# Altium schematic sheet SCM20260E-Micro.SchDoc
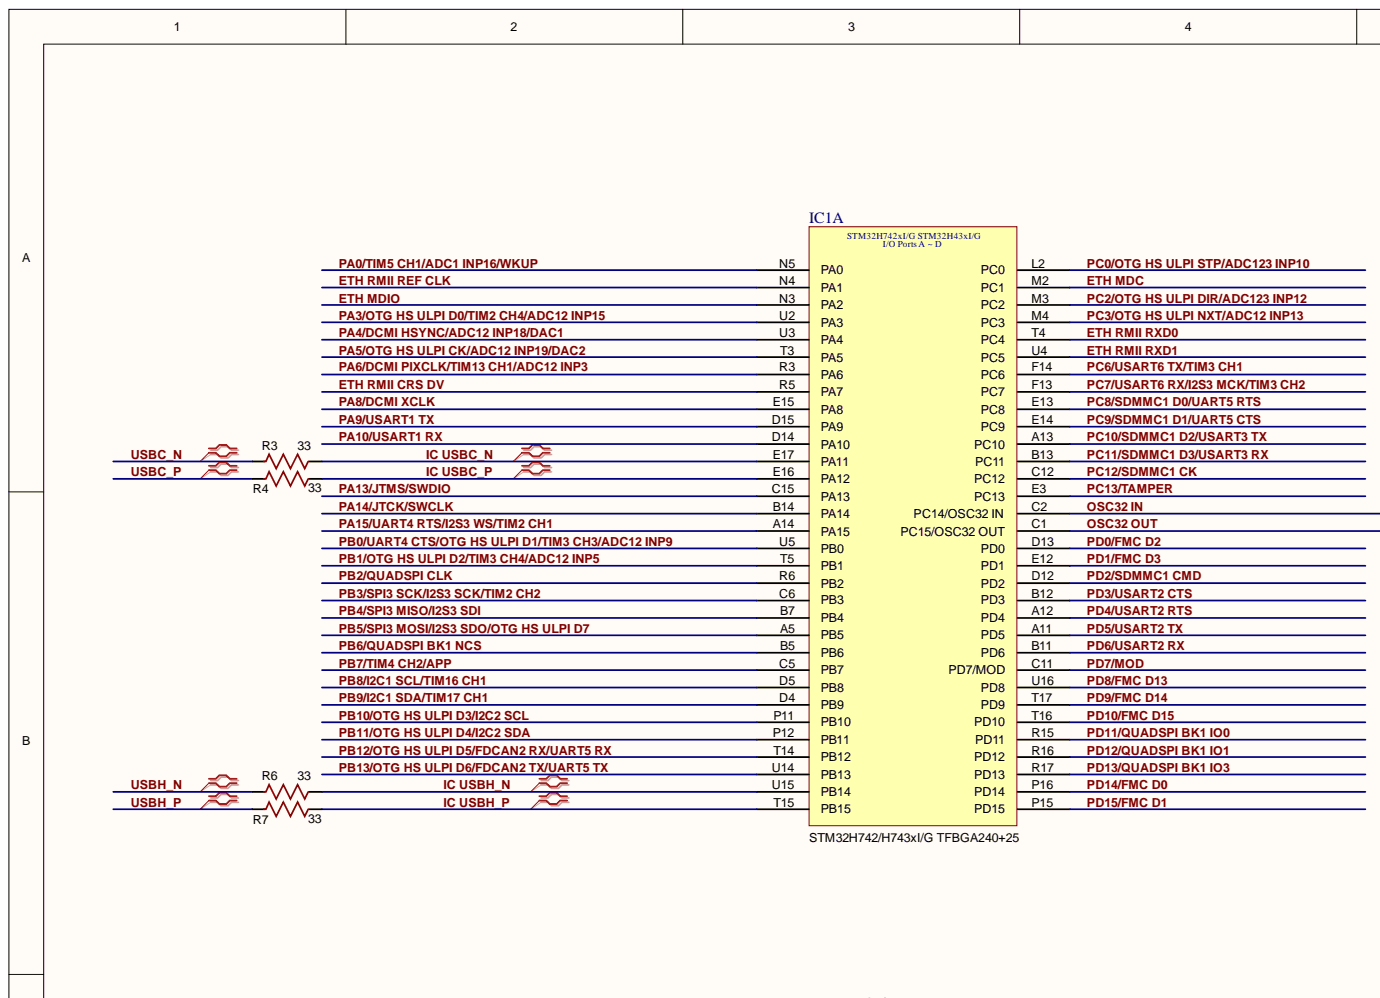
[diagram p0: SCM20260E-Micro.SchDoc, top left]
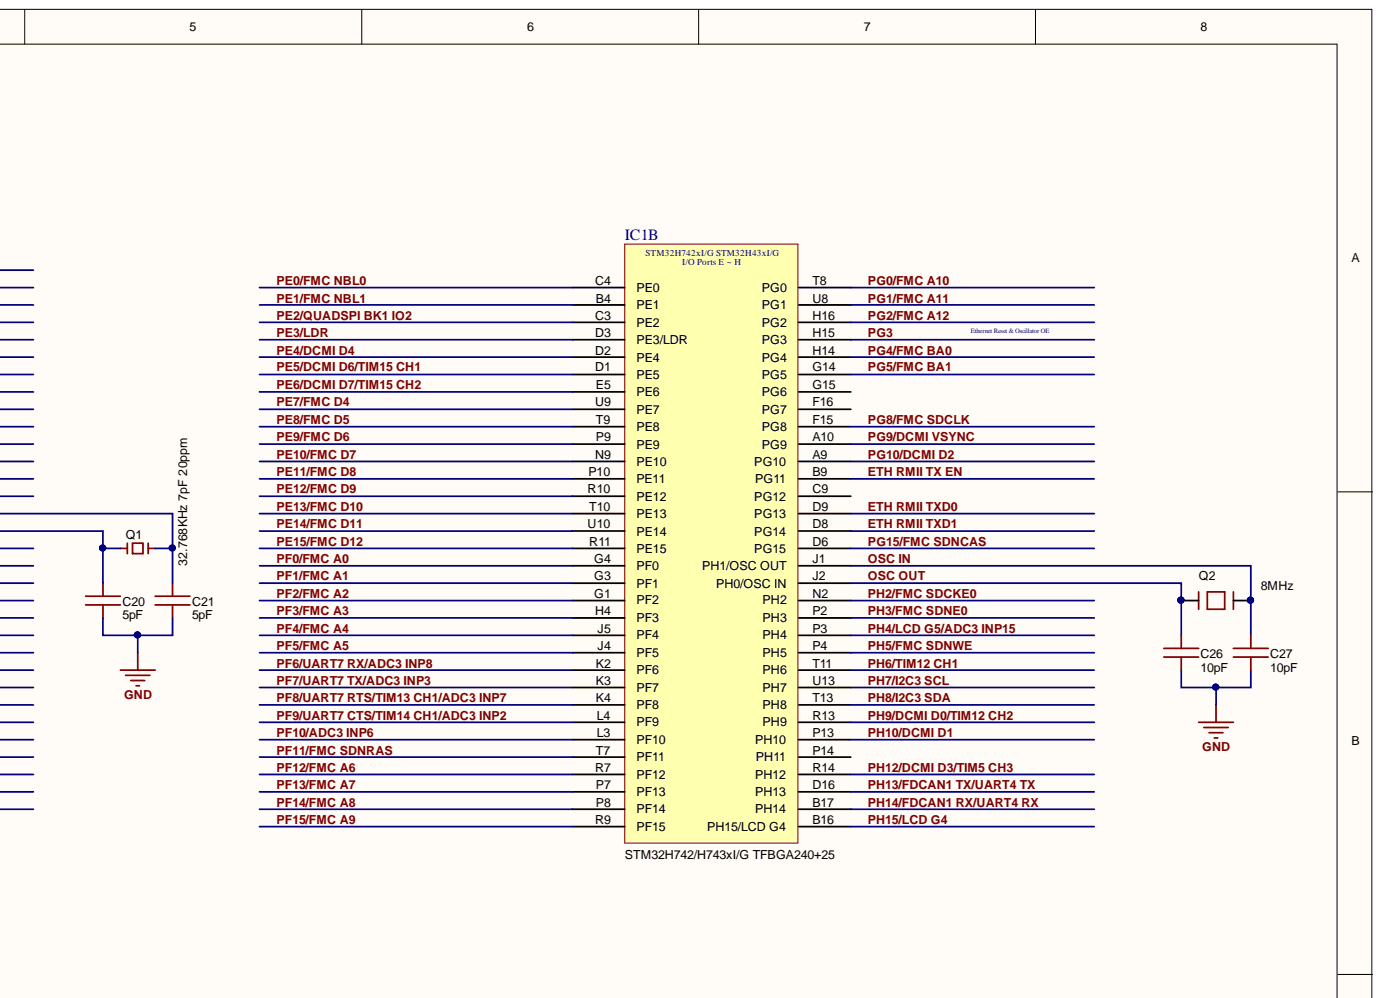
[diagram p1: SCM20260E-Micro.SchDoc, top right]
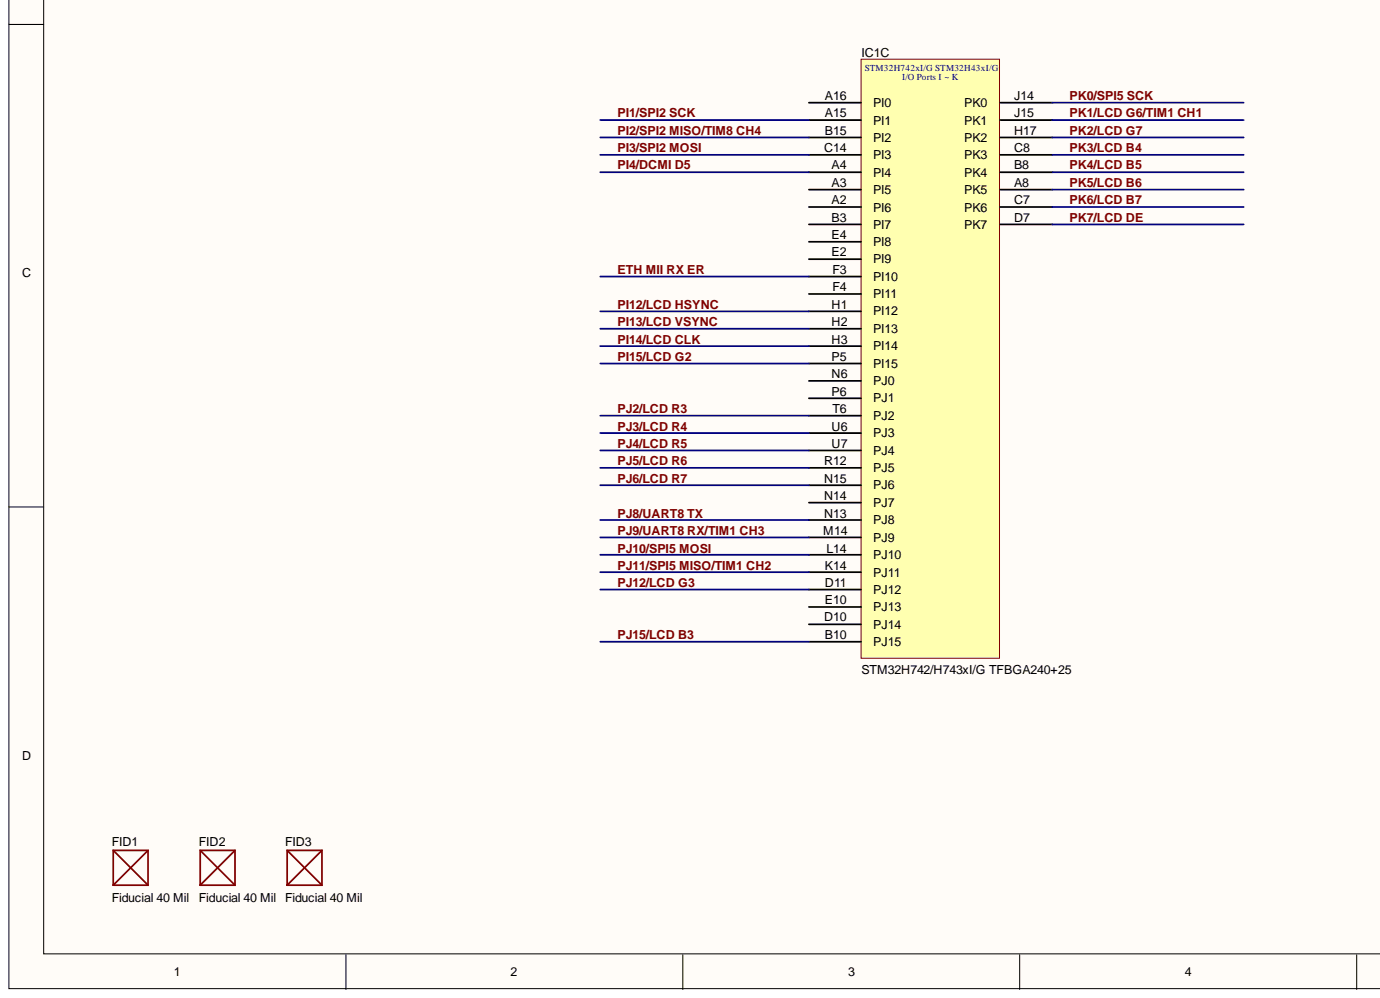
[diagram p2: SCM20260E-Micro.SchDoc, bottom left]
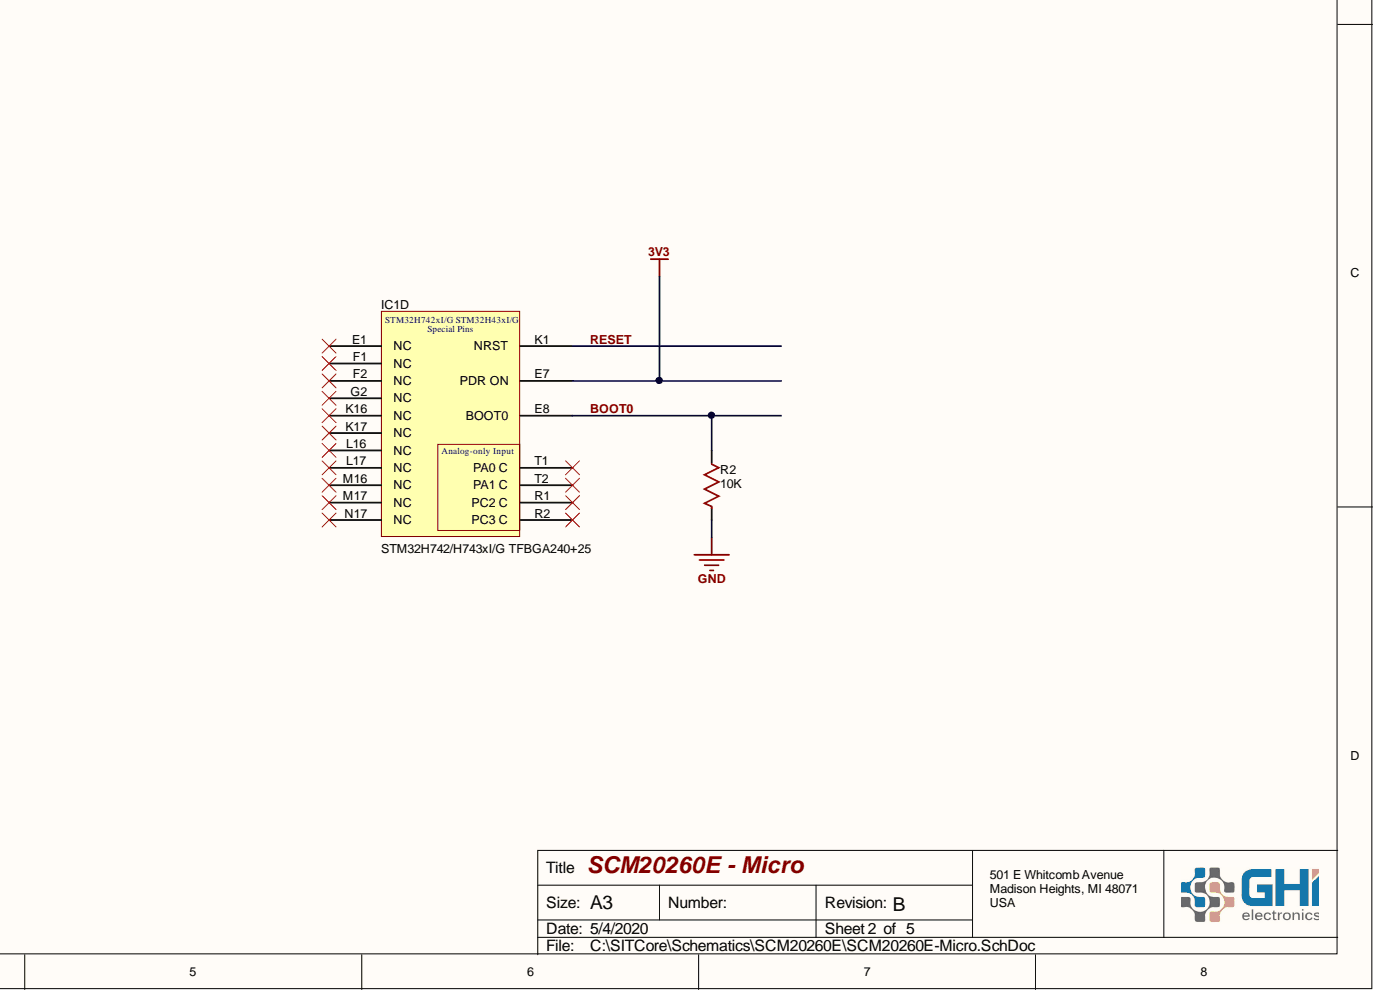
[diagram p3: SCM20260E-Micro.SchDoc, bottom right]

## Parts
C20  value 5pF  Capacitance: 5pF  [C0402]  (hidden comment: 0402 Cap 5pF)
C21  value 5pF  Capacitance: 5pF  [C0402]  (hidden comment: 0402 Cap 5pF)
C26  value 10pF  Capacitance: 10pF  [C0402]  (hidden comment: 0402 Cap 10pF)
C27  value 10pF  Capacitance: 10pF  [C0402]  (hidden comment: 0402 Cap 10pF)
FID1  Fiducial 40 Mil  [Fiducial 40 Mil]  (no BOM)
FID2  Fiducial 40 Mil  [Fiducial 40 Mil]  (no BOM)
FID3  Fiducial 40 Mil  [Fiducial 40 Mil]  (no BOM)
IC1  STM32H742/H743xI/G TFBGA240+25  [TFBGA240+25]  (parts A,B,C,D)
Q1  32.768KHz 7pF 20ppm  Output Frequency: 32.768KHz  [Crystal 3.2mm x 1.5mm]
Q2  value 8MHz  [CRYSTAL_3.2X2.5]  (hidden comment: CRYSTAL3.2X2.5)
R2  value 10K  Resistance: 10K  [R0402]  (hidden comment: 0402 Res 10K)
R3  value 33  [R0402 (Metric 1005)]  (hidden comment: 0402 R)
R4  value 33  [R0402 (Metric 1005)]  (hidden comment: 0402 R)
R6  value 33  [R0402 (Metric 1005)]  (hidden comment: 0402 R)
R7  value 33  [R0402 (Metric 1005)]  (hidden comment: 0402 R)

## Nets
3V3: IC1.E7(PDR_ON)
GND: C20.1 C21.1 C26.1 C27.1 R2.1
BOOT0: IC1.E8(BOOT0) R2.2
ETH MDC: IC1.M2(PC1)
ETH MDIO: IC1.N3(PA2)
ETH MII RX ER: IC1.F3(PI10)
ETH RMII CRS DV: IC1.R5(PA7)
ETH RMII REF CLK: IC1.N4(PA1)
ETH RMII RXD0: IC1.T4(PC4)
ETH RMII RXD1: IC1.U4(PC5)
ETH RMII TX EN: IC1.B9(PG11)
ETH RMII TXD0: IC1.D9(PG13)
ETH RMII TXD1: IC1.D8(PG14)
IC USBC_N: IC1.E17(PA11) R3.1
IC USBC_P: IC1.E16(PA12) R4.1
IC USBH_N: IC1.U15(PB14) R6.1
IC USBH_P: IC1.T15(PB15) R7.1
OSC32 IN: C21.2 IC1.C2(PC14/OSC32_IN) Q1.2
OSC32 OUT: C20.2 IC1.C1(PC15/OSC32_OUT) Q1.1
OSC IN: C27.2 IC1.J1(PH1/OSC_OUT) Q2.3
OSC OUT: C26.2 IC1.J2(PH0/OSC_IN) Q2.1
PA0/TIM5 CH1/ADC1 INP16/WKUP: IC1.N5(PA0)
PA3/OTG HS ULPI D0/TIM2 CH4/ADC12 INP15: IC1.U2(PA3)
PA4/DCMI HSYNC/ADC12 INP18/DAC1: IC1.U3(PA4)
PA5/OTG HS ULPI CK/ADC12 INP19/DAC2: IC1.T3(PA5)
PA6/DCMI PIXCLK/TIM13 CH1/ADC12 INP3: IC1.R3(PA6)
PA8/DCMI XCLK: IC1.E15(PA8)
PA9/USART1 TX: IC1.D15(PA9)
PA10/USART1 RX: IC1.D14(PA10)
PA13/JTMS/SWDIO: IC1.C15(PA13)
PA14/JTCK/SWCLK: IC1.B14(PA14)
PA15/UART4 RTS/I2S3 WS/TIM2 CH1: IC1.A14(PA15)
PB0/UART4 CTS/OTG HS ULPI D1/TIM3 CH3/ADC12 INP9: IC1.U5(PB0)
PB1/OTG HS ULPI D2/TIM3 CH4/ADC12 INP5: IC1.T5(PB1)
PB2/QUADSPI CLK: IC1.R6(PB2)
PB3/SPI3 SCK/I2S3 SCK/TIM2 CH2: IC1.C6(PB3)
PB4/SPI3 MISO/I2S3 SDI: IC1.B7(PB4)
PB5/SPI3 MOSI/I2S3 SDO/OTG HS ULPI D7: IC1.A5(PB5)
PB6/QUADSPI BK1 NCS: IC1.B5(PB6)
PB7/TIM4 CH2/APP: IC1.C5(PB7)
PB8/I2C1 SCL/TIM16 CH1: IC1.D5(PB8)
PB9/I2C1 SDA/TIM17 CH1: IC1.D4(PB9)
PB10/OTG HS ULPI D3/I2C2 SCL: IC1.P11(PB10)
PB11/OTG HS ULPI D4/I2C2 SDA: IC1.P12(PB11)
PB12/OTG HS ULPI D5/FDCAN2 RX/UART5 RX: IC1.T14(PB12)
PB13/OTG HS ULPI D6/FDCAN2 TX/UART5 TX: IC1.U14(PB13)
PC0/OTG HS ULPI STP/ADC123 INP10: IC1.L2(PC0)
PC2/OTG HS ULPI DIR/ADC123 INP12: IC1.M3(PC2)
PC3/OTG HS ULPI NXT/ADC12 INP13: IC1.M4(PC3)
PC6/USART6 TX/TIM3 CH1: IC1.F14(PC6)
PC7/USART6 RX/I2S3 MCK/TIM3 CH2: IC1.F13(PC7)
PC8/SDMMC1 D0/UART5 RTS: IC1.E13(PC8)
PC9/SDMMC1 D1/UART5 CTS: IC1.E14(PC9)
PC10/SDMMC1 D2/USART3 TX: IC1.A13(PC10)
PC11/SDMMC1 D3/USART3 RX: IC1.B13(PC11)
PC12/SDMMC1 CK: IC1.C12(PC12)
PC13/TAMPER: IC1.E3(PC13)
PD0/FMC D2: IC1.D13(PD0)
PD1/FMC D3: IC1.E12(PD1)
PD2/SDMMC1 CMD: IC1.D12(PD2)
PD3/USART2 CTS: IC1.B12(PD3)
PD4/USART2 RTS: IC1.A12(PD4)
PD5/USART2 TX: IC1.A11(PD5)
PD6/USART2 RX: IC1.B11(PD6)
PD7/MOD: IC1.C11(PD7/MOD)
PD8/FMC D13: IC1.U16(PD8)
PD9/FMC D14: IC1.T17(PD9)
PD10/FMC D15: IC1.T16(PD10)
PD11/QUADSPI BK1 IO0: IC1.R15(PD11)
PD12/QUADSPI BK1 IO1: IC1.R16(PD12)
PD13/QUADSPI BK1 IO3: IC1.R17(PD13)
PD14/FMC D0: IC1.P16(PD14)
PD15/FMC D1: IC1.P15(PD15)
PE0/FMC NBL0: IC1.C4(PE0)
PE1/FMC NBL1: IC1.B4(PE1)
PE2/QUADSPI BK1 IO2: IC1.C3(PE2)
PE3/LDR: IC1.D3(PE3/LDR)
PE4/DCMI D4: IC1.D2(PE4)
PE5/DCMI D6/TIM15 CH1: IC1.D1(PE5)
PE6/DCMI D7/TIM15 CH2: IC1.E5(PE6)
PE7/FMC D4: IC1.U9(PE7)
PE8/FMC D5: IC1.T9(PE8)
PE9/FMC D6: IC1.P9(PE9)
PE10/FMC D7: IC1.N9(PE10)
PE11/FMC D8: IC1.P10(PE11)
PE12/FMC D9: IC1.R10(PE12)
PE13/FMC D10: IC1.T10(PE13)
PE14/FMC D11: IC1.U10(PE14)
PE15/FMC D12: IC1.R11(PE15)
PF0/FMC A0: IC1.G4(PF0)
PF1/FMC A1: IC1.G3(PF1)
PF2/FMC A2: IC1.G1(PF2)
PF3/FMC A3: IC1.H4(PF3)
PF4/FMC A4: IC1.J5(PF4)
PF5/FMC A5: IC1.J4(PF5)
PF6/UART7 RX/ADC3 INP8: IC1.K2(PF6)
PF7/UART7 TX/ADC3 INP3: IC1.K3(PF7)
PF8/UART7 RTS/TIM13 CH1/ADC3 INP7: IC1.K4(PF8)
PF9/UART7 CTS/TIM14 CH1/ADC3 INP2: IC1.L4(PF9)
PF10/ADC3 INP6: IC1.L3(PF10)
PF11/FMC SDNRAS: IC1.T7(PF11)
PF12/FMC A6: IC1.R7(PF12)
PF13/FMC A7: IC1.P7(PF13)
PF14/FMC A8: IC1.P8(PF14)
PF15/FMC A9: IC1.R9(PF15)
PG0/FMC A10: IC1.T8(PG0)
PG1/FMC A11: IC1.U8(PG1)
PG2/FMC A12: IC1.H16(PG2)
PG3: IC1.H15(PG3)
PG4/FMC BA0: IC1.H14(PG4)
PG5/FMC BA1: IC1.G14(PG5)
PG8/FMC SDCLK: IC1.F15(PG8)
PG9/DCMI VSYNC: IC1.A10(PG9)
PG10/DCMI D2: IC1.A9(PG10)
PG15/FMC SDNCAS: IC1.D6(PG15)
PH2/FMC SDCKE0: IC1.N2(PH2)
PH3/FMC SDNE0: IC1.P2(PH3)
PH4/LCD G5/ADC3 INP15: IC1.P3(PH4)
PH5/FMC SDNWE: IC1.P4(PH5)
PH6/TIM12 CH1: IC1.T11(PH6)
PH7/I2C3 SCL: IC1.U13(PH7)
PH8/I2C3 SDA: IC1.T13(PH8)
PH9/DCMI D0/TIM12 CH2: IC1.R13(PH9)
PH10/DCMI D1: IC1.P13(PH10)
PH12/DCMI D3/TIM5 CH3: IC1.R14(PH12)
PH13/FDCAN1 TX/UART4 TX: IC1.D16(PH13)
PH14/FDCAN1 RX/UART4 RX: IC1.B17(PH14)
PH15/LCD G4: IC1.B16(PH15/LCD_G4)
PI1/SPI2 SCK: IC1.A15(PI1)
PI2/SPI2 MISO/TIM8 CH4: IC1.B15(PI2)
PI3/SPI2 MOSI: IC1.C14(PI3)
PI4/DCMI D5: IC1.A4(PI4)
PI12/LCD HSYNC: IC1.H1(PI12)
PI13/LCD VSYNC: IC1.H2(PI13)
PI14/LCD CLK: IC1.H3(PI14)
PI15/LCD G2: IC1.P5(PI15)
PJ2/LCD R3: IC1.T6(PJ2)
PJ3/LCD R4: IC1.U6(PJ3)
PJ4/LCD R5: IC1.U7(PJ4)
PJ5/LCD R6: IC1.R12(PJ5)
PJ6/LCD R7: IC1.N15(PJ6)
PJ8/UART8 TX: IC1.N13(PJ8)
PJ9/UART8 RX/TIM1 CH3: IC1.M14(PJ9)
PJ10/SPI5 MOSI: IC1.L14(PJ10)
PJ11/SPI5 MISO/TIM1 CH2: IC1.K14(PJ11)
PJ12/LCD G3: IC1.D11(PJ12)
PJ15/LCD B3: IC1.B10(PJ15)
PK0/SPI5 SCK: IC1.J14(PK0)
PK1/LCD G6/TIM1 CH1: IC1.J15(PK1)
PK2/LCD G7: IC1.H17(PK2)
PK3/LCD B4: IC1.C8(PK3)
PK4/LCD B5: IC1.B8(PK4)
PK5/LCD B6: IC1.A8(PK5)
PK6/LCD B7: IC1.C7(PK6)
PK7/LCD DE: IC1.D7(PK7)
RESET: IC1.K1(NRST)
USBC_N: R3.2
USBC_P: R4.2
USBH_N: R6.2
USBH_P: R7.2

Not connected: IC1 A2(PI6) A3(PI5) A16(PI0) B3(PI7) C9(PG12) D10(PJ14) E1(NC) E2(PI9) E4(PI8) E10(PJ13) F1(NC) F2(NC) F4(PI11) F16(PG7) G2(NC) G15(PG6) K16(NC) K17(NC) L16(NC) L17(NC) M16(NC) M17(NC) N6(PJ0) N14(PJ7) N17(NC) P6(PJ1) P14(PH11) R1(PC2_C) R2(PC3_C) T1(PA0_C) T2(PA1_C)

## Library data of the parts
C20 C21 = 0402 Cap 5pF: 5pF ±0.1pF 50V C0G 0402 Multilayer Ceramic Capacitors MLCC - SMD/SMT RoHS; Manufacturer: Samsung Electro-Mechanics; Mfr.Part #: CL05C050BB5NNNC; Category: Ceramic; Component Kind: Standard; LCSC Part #: C318616; Package: 0402; Tolerance: ±0.1pF; Voltage: 50V; Library Name: GHI-Passives.SchLib
C26 C27 = 0402 Cap 10pF: 10pF ±0.5pF 50V C0G 0402 Multilayer Ceramic Capacitors MLCC - SMD/SMT RoHS; Manufacturer: Samsung Electro-Mechanics; Mfr.Part #: CL05C100DB5NNNC; Category: Ceramic; Component Kind: Standard; LCSC Part #: C307440; Package: 0402; Tolerance: ±0.5pF; Voltage: 50V; Library Name: GHI-Passives.SchLib
IC1 = STM32H742/H743xI/G TFBGA240+25: STM32H742/H743xI/G TFBGA240+25 (14 x 14mm); Component Kind: Standard; Library Name: GHI Processors.SchLib
Q1 = 32.768KHz 7pF 20ppm 2 Pad 3.2mm x 1.5mm: Crystal 2 Pad 3.2mm x 1.5mm 32.768KHz; GHI Part Number: EPC-Q32KHZ; Manufacturer Part Number: 6K30032768K0702070401; Aging @25 C 1st Year (Max): +- 3ppm/year; Driver Level (Typical): 1uW Max; Equivalent Series Resistance: 70k Ohm Max; Frequency Tolerance: +- 20ppm; Load Capacitance: 10 pF; Mode: AT/Fundamental; Shunt Capacitance: 1.3pF Typ; Component Kind: Standard; Frequency Stability: N/A; Library Name: GHI Oscillators.SchLib; Operating Temperature Range: -40 C to +85 C; Shock Resistance: N/A; Storage Temperature: -55C to +125 C
Q2 = CRYSTAL3.2X2.5: 4 pad 3.2 mm x 2.5 mm Crystal; GateName_1: G$1; SymbolName_1: CRYSTAL.2PAD; Component Kind: Standard; DeviceSetName: CRYSTAL3.2X2.5; LibraryName: GHI2; Package: 3225; Library Name: GHI.SchLib
R2 = 0402 Res 10K: 10K ±1% 1/16W ±100ppm/℃ 0402 Chip Resistor - Surface Mount RoHS; Manufacturer: YAGEO; Mfr.Part #: RC0402FR-0710KL; Voltage Rating: *V; Component Kind: Standard; LCSC Part #: C60490; Package: 0402; Power Rating: 1/16W; Resistance Tolerance: ±1%; Library Name: GHI-Passives.SchLib
R3 R4 R6 R7 = 0402 Res 33: 33 ±1% 1/16W ±100ppm/℃ 0402 Chip Resistor - Surface Mount RoHS; Manufacturer: YAGEO; Mfr.Part #: RC0402FR-0733RL; Voltage Rating: *V; Component Kind: Standard; LCSC Part #: C138002; Package: 0402; Power Rating: 1/16W; Resistance: 33; Resistance Tolerance: ±1%; Library Name: GHI-Passives.SchLib
FID1-FID3 = Fiducial 40 Mil: Library Name: GHI Miscellaneous Components.SchLib
